# Altium schematic sheet Power.SchDoc(Power)
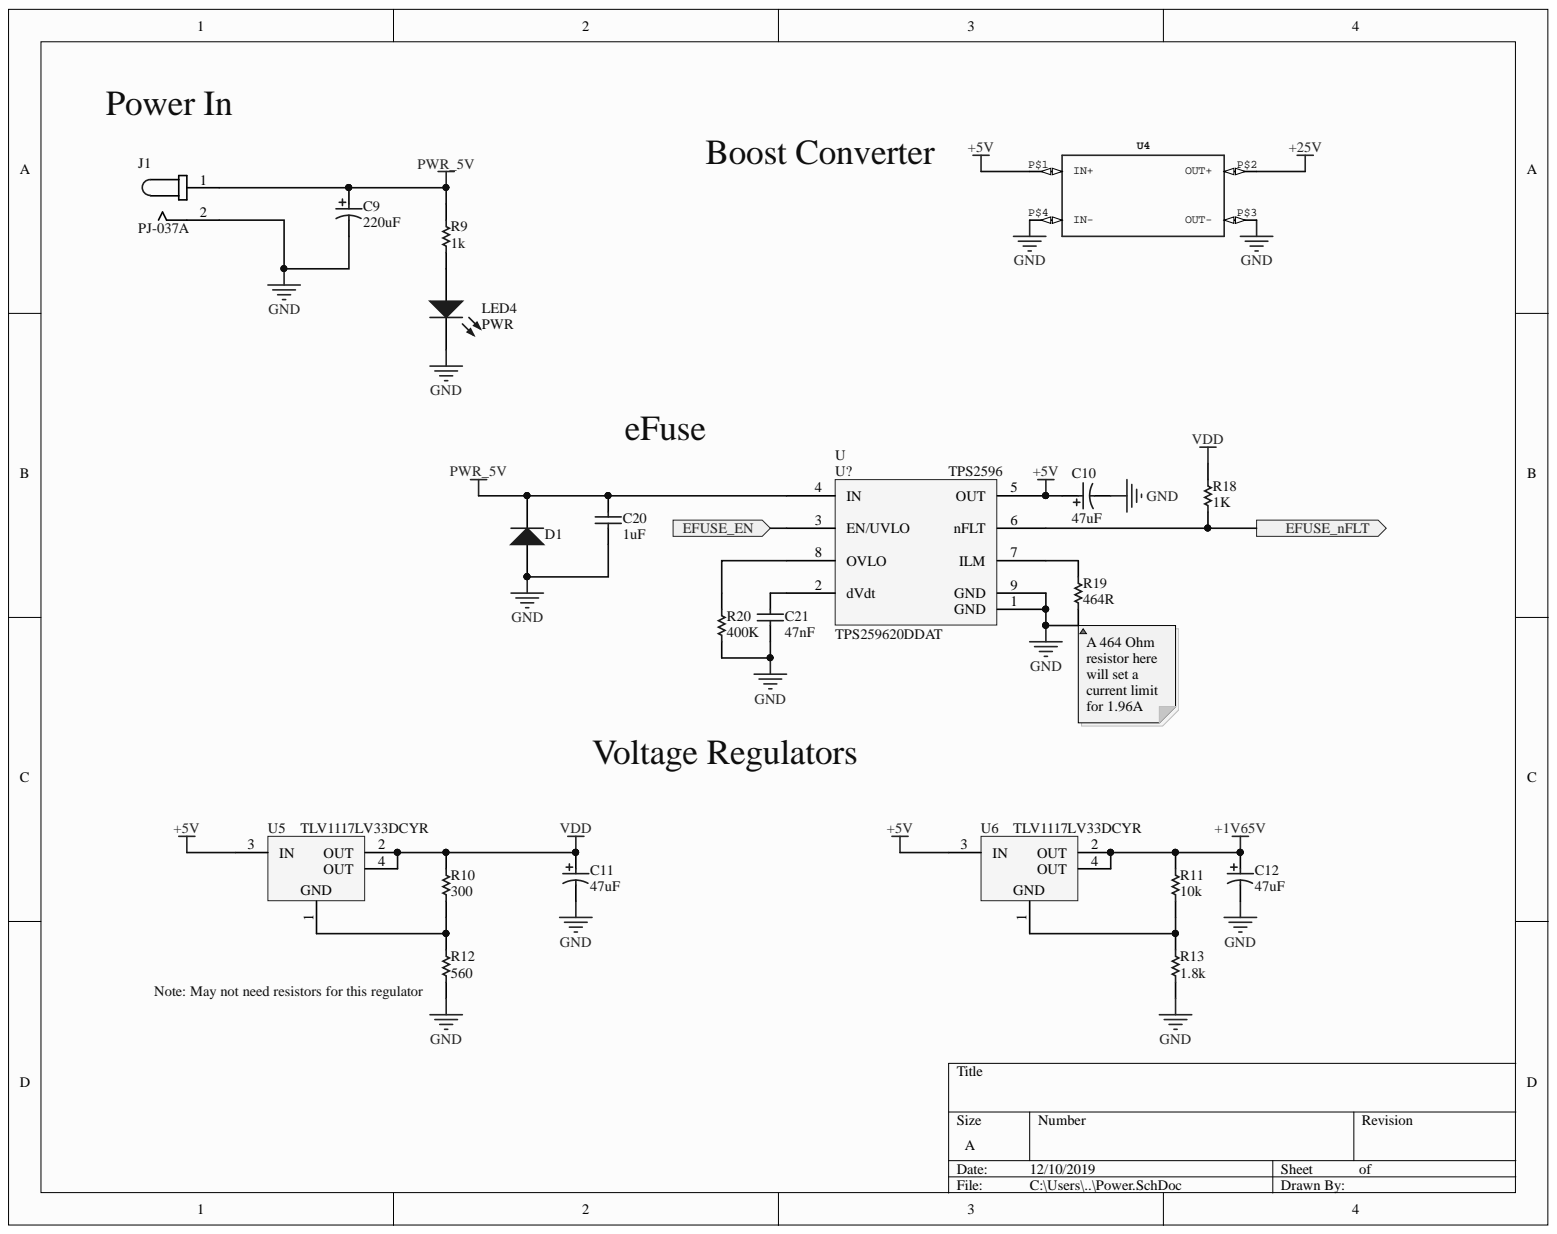
[diagram p0: Power.SchDoc]

## Parts
C9  220uF  [PNSC-FP-V-F_V]
C10  47uF  [PNSC-FP-V-D_V]
C11  47uF  [PNSC-FP-V-D_V]
C12  47uF  [PNSC-FP-V-D_V]
C20  1uF  [CAPC1608X90X20LL10]
C21  47nF  [CAPC1608X90X35ML10T15]
D1  SMAJ5.0A-E3/61  [VISH-DO-214AC-2_M]  (value not drawn)
J1  PJ-037A  [*CUI_PJ-037A]
LED4  PWR  [0805_A]
R9  1k  [RESC1608X55X30LL20T20]
R10  300  [RESC1608X55X30LL15T15]
R11  10k  [RESC1608X55X30LL15T15]
R12  560  [RESC1608X55X30LL20T20]
R13  1.8k  [RESC1609X50X30NL10T20]
R18  1K  [RESC1608X55X30LL15T15]
R19  464R  [RESC1608X55X30LL15T15]
R20  400K  [RESC1608X55X30LL15T15]
U  TPS259620DDAT  [TPS259620DDAT - duplicate]
U4  XL6009E1  [XL6009E1]  (value not drawn)
U5  TLV1117LV33DCYR  [DCY0004A_M]
U6  TLV1117LV33DCYR  [DCY0004A_M]

## Ports
EFUSE_EN, EFUSE_nFLT

## Nets
+1V65V: C12.1 R11.2 U6.2(OUT) U6.4(OUT)
+5V: C10.1 U.5(OUT) U4.P$1(IN+) U5.3(IN) U6.3(IN)
+25V: U4.P$2(OUT+)
GND: C9.2 C10.2 C11.2 C12.2 C20.1 C21.1 D1.2 J1.2 LED4.1 R12.1 R13.2 R19.1 R20.1 U.1(GND) U.9(GND) U4.P$3(OUT-) U4.P$4(IN-)
PWR_5V: C9.1 C20.2 D1.1 J1.1 R9.2 U.4(IN)
VDD: C11.1 R10.2 R18.2 U5.2(OUT) U5.4(OUT)
EFUSE_EN: U.3(EN/UVLO) ; port EFUSE_EN
EFUSE_nFLT: R18.1 U.6(nFLT) ; port EFUSE_nFLT
NetC21_2: C21.2 U.2(dVdt)
NetLED4_2: LED4.2 R9.1
NetR10_1: R10.1 R12.2 U5.1
NetR11_1: R11.1 R13.1 U6.1
NetR19_2: R19.2 U.7(ILM)
NetR20_2: R20.2 U.8(OVLO)

## Library data of the parts
C9 = CMP-2000-06215-1: Aluminum Electrolytic Capacitor, 220 uF, +/- 20%, 35 V, 2-Pin SMD (Size Code F), -55 to 105 degC, RoHS, Tape and Reel; Manufacturer: Panasonic; Voltage Rating: 35V; SVHC: ToBeAdvised; Ripple Current AC: 850mA; Product Range: PANASONIC-FPSeries; Lifetime @ Temperature: 2000hours@105°C; Leakage Current: 7.7µA; External Length / Height: 10.2mm; ESR: 0.08ohm; Capacitor Case Style: RadialCan-SMD; Capacitance: 220µF; Series: FP; Packaging: CutTape; Package URL: http://industrial.panasonic.com/cdbs/www-data/pdf/RDE0000/DME0000COL48.pdf; Package Reference: FP-V-F; Package Description: 2-Pin Electrolytic Aluminum Capacitor, Body 8.3 x 8.3 mm; Operating Temperature Range: -55°Cto+105°C; Operating Temperature Min: -55°C; Operating Temperature Max: 105°C; Mounting Technology: SurfaceMount; Manufacturer URL: http://www.panasonic.com/; Life Time @ Temperature: 2000hours@105°C; Library Name: Altium Content Vault; Height: 10.2mm; Diameter: 8mm; Datasheet Version: 03/2016; Datasheet URL: http://industrial.panasonic.com/cdbs/www-data/pdf/RDE0000/ABA0000C1184.pdf; Component Kind: Standard; Capacitance Tolerance ±: ±20%; Capacitance Tolerance: ±20%; AE Capacitor Case: RadialCan-SMD
C10-C12 = CMP-2000-06216-1: Aluminum Electrolytic Capacitor, 47 uF, +/- 20%, 35 V, 2-Pin SMD (Size Code D), -55 to 105 degC, RoHS, Tape and Reel; Manufacturer: Panasonic; Type: Can; Ripple Current: 300mA; Rated Voltage: 35V; Lifetime@Temperature: 2000Hrs@105°C; ESR (Equivalent Series Resistance): 0.26O; Capacitance: 47µF; Series: FP; Package URL: http://industrial.panasonic.com/cdbs/www-data/pdf/RDE0000/DME0000COL48.pdf; Package Reference: FP-V-D; Package Description: 2-Pin Electrolytic Aluminum Capacitor, Body 6.6 x 6.6 mm; Operating Temp Range: -55to+105°C; Operating Temp-Max: 105°C; Mounting Technology: SurfaceMount; Manufacturer URL: http://www.panasonic.com/; Library Name: Altium Content Vault; Datasheet Version: 03/2016; Datasheet URL: http://industrial.panasonic.com/cdbs/www-data/pdf/RDE0000/ABA0000C1184.pdf; Component Kind: Standard; Case Size/Dimension: Ø6.3x5.8mm
C20 = CMP-2000-06025-1: Chip Capacitor, 1 uF, +/- 10%, 50 V, -55 to 85 degC, 0603 (1608 Metric), RoHS, Tape and Reel; PCN Part Number: MLCCPartNumberChange30/Nov/2012; Manufacturer: TDK; Voltage - Rated: 50V; Temperature Coefficient: X5R; Product Training Modules: CSeriesGeneralApplicationsSEAT,CCV,andTVCLDesignToolsGuidetoReplacinganElectrolyticCapacitorwithanMLCC; Other Names: 445-7468-6; Online Catalog: CSeries,GeneralPurpose; Design Resources: 20WNon-IsolatedBuckLEDDriverUsingPLLNK460KG; Capacitance: 1µF; Tolerance: ±10%; Thickness (Max): 0.035"(0.90mm); Standard Package: 1; Size / Dimension: 0.063"Lx0.031"W(1.60mmx0.80mm); Series: C; RoHS Information: RoHSCertificate-MLCC; Ratings: -; Packaging: Digi-Reel®; Package Reference: 0603; Package Description: 2-Pin Surface Mount Device, Body 1.6 x 0.8 mm; Package / Case: 0603(1608Metric); Operating Temperature: -55°C~85°C; Mounting Type: SurfaceMount,MLCC; Mounting Technology: SurfaceMount; Manufacturer URL: http://www.tdk.com/; Library Name: Altium Content Vault; Lead Style: -; Lead Spacing: -; Height - Seated (Max): -; Features: -; Family: CeramicCapacitors; Datasheet Version: 08/2016; Datasheet URL: https://product.tdk.com/info/en/catalog/datasheets/mlcc_commercial_general_en.pdf; Component Kind: Standard; Category: Capacitors; Applications: GeneralPurpose
C21 = CMP-2006-02927-1: Part Number: GRM188R71H473KA61D; Manufacturer: Murata; Library Name: Altium Content Vault; Component Kind: Standard
D1 = CMP-0548-00235-2: Transient Voltage Suppressor, 6.40 to 231 V, 5 V Stand-off Voltage, Uni-Directional, -55 to 155 degC, 2-pin DO-214AC, Pb-Free, Tape and Reel; Manufacturer: Vishay Semiconductor; VWM (V): 5; VBR Uni-Directional (V): 6.4 to 231; PPPM (W): 400, 300; IFSM: 40 A; ID (uA): 800; RoHS: TRUE; PartNumber: SMAJ5.0A-E3/61; Packing: Tape and Reel; PackageReference: DO-214AC-2; PackageDescription: 2-Pin DO-214AC (SMA), Body 4.245 x 2.665 mm; Operating Junction and Storage Temperature Range: -55 to 150 degC; Mounting Technology: Surface Mount; Library Name: Altium Content Vault; DatasheetVersion: 12/8/2013; ComponentLink3URL: http://www.vishay.com/docs/88390/smaj50a.pdf; ComponentLink3Description: Package Specification; ComponentLink2URL: http://www.vishay.com/docs/88390/smaj50a.pdf; ComponentLink2Description: Datasheet; ComponentLink1URL: http://www.vishay.com/; ComponentLink1Description: Manufacturer URL; Component Kind: Standard
LED4 = CMP-1426-00010-1: SMD mono-color Chip LED, WL-SMCW, Super Red; PartNumber: 150080SS75000; Manufacturer: Wuerth Elektronik; PackageReference: 0805_A; Library Name: Altium Content Vault; ComponentLink2URL: http://katalog.we-online.com/led/datasheet/150080SS75000.pdf; ComponentLink2Description: Datasheet; ComponentLink1URL: http://www.we-online.com; ComponentLink1Description: Manufacturer URL; Component Kind: Standard; Color: Super Red
R9 = CMP-2000-00873-1: Part Number: ERA3AEB102V; Manufacturer: Panasonic; Library Name: Altium Content Vault; Component Kind: Standard
R10 R11 R18-R20 = CMP-2000-00411-1: Part Number: ERJ-3EKF3000V; Manufacturer: Panasonic; Library Name: Altium Content Vault; Component Kind: Standard
R12 = CMP-2000-00091-1: Part Number: ERA3AEB561V; Manufacturer: Panasonic; Library Name: Altium Content Vault; Component Kind: Standard
R13 = CMP-2000-03824-1: Part Number: CRCW0603100RFKEA; Manufacturer: Vishay Dale; Library Name: Altium Content Vault; Component Kind: Standard
U = TI_TPS259620DDAT: Texas Instruments TPS259620DDAT; Library Name: TI_TPS259620DDAT.SchLib; ComponentLink1URL: http://www.ti.com/product/TPS2596; ComponentLink1Description: TI Link; Component Kind: Standard
U4 = XL6009E1: GateName_1: G$1; SymbolName_1: XL6009E1; Package: XL6009E1; DeviceSetName: XL6009E1; Component Kind: Standard; LibraryName: VFD-Clock; Library Name: VFD-Clock.IntLib
U5 U6 = CMP-0395-00503-2: Single Output LDO, 1 A, Fixed 3.3 V Output, 2 to 5.5 V Input, 4-pin SOT-223 (DCY), -40 to 125 degC, Green (RoHS & no Sb/Br); Manufacturer: Texas Instruments; Vout Adj(Min)(V): 3.3; Vin(Min)(V): 2; Vin(Max)(V): 5.5; Vdo(Typ)(mV): 1200; SubFamily: Single Channel LDO; Iq(Typ)(mA): 0.05; Iout(Max)(A): 1; Vout Adj(Max)(V): 3.3; PartNumber: TLV1117LV33DCYR; PackageVersion: 4202506/B; PackageReference: DCY0004A; PackageDescription: 4-Pin Plastic Small Outline, Body 3.5 x 6.5 mm, Pitch 2.3 mm; Mounting Technology: Surface Mount; Fixed Output Options(V): 3.3; Eco-Plan: Green (RoHS & no Sb/Br); DatasheetVersion: SBVS160A; ComponentLink3URL: http://www.ti.com/litv/pdf/mpds094a; ComponentLink3Description: Package Specification; ComponentLink2URL: http://www.ti.com/general/docs/lit/getliterature.tsp?genericPartNumber=TLV1117LV33&fileType=pdf; ComponentLink2Description: Datasheet; ComponentLink1URL: http://www.ti.com/; ComponentLink1Description: Manufacturer URL; Component Kind: Standard; Code_JEDEC: TO-261; Accuracy(%): 2; Library Name: VFD_Clock_lib.IntLib
J1 = PJ-037A: Library Name: PJ-037A.IntLib; Component Kind: Standard
